# Altium schematic sheet ICY40_A02_DIPSW-DISPLAY.SchDoc
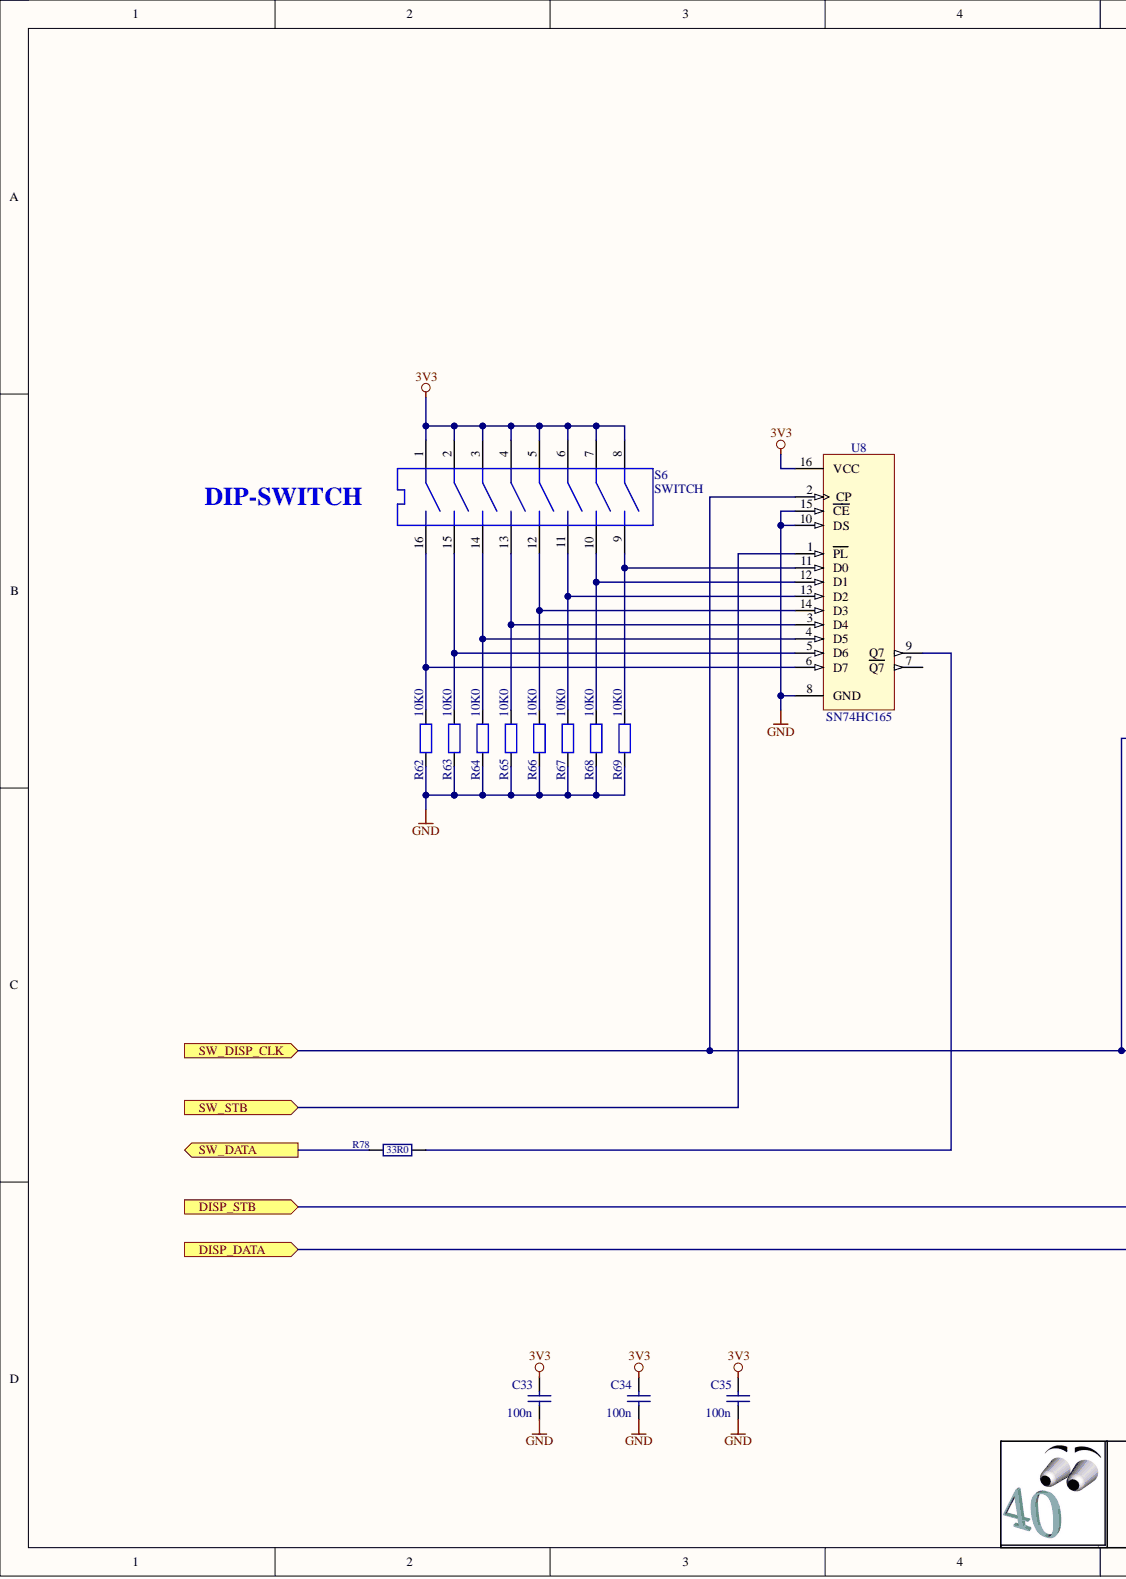
[diagram p0: ICY40_A02_DIPSW-DISPLAY.SchDoc, left]
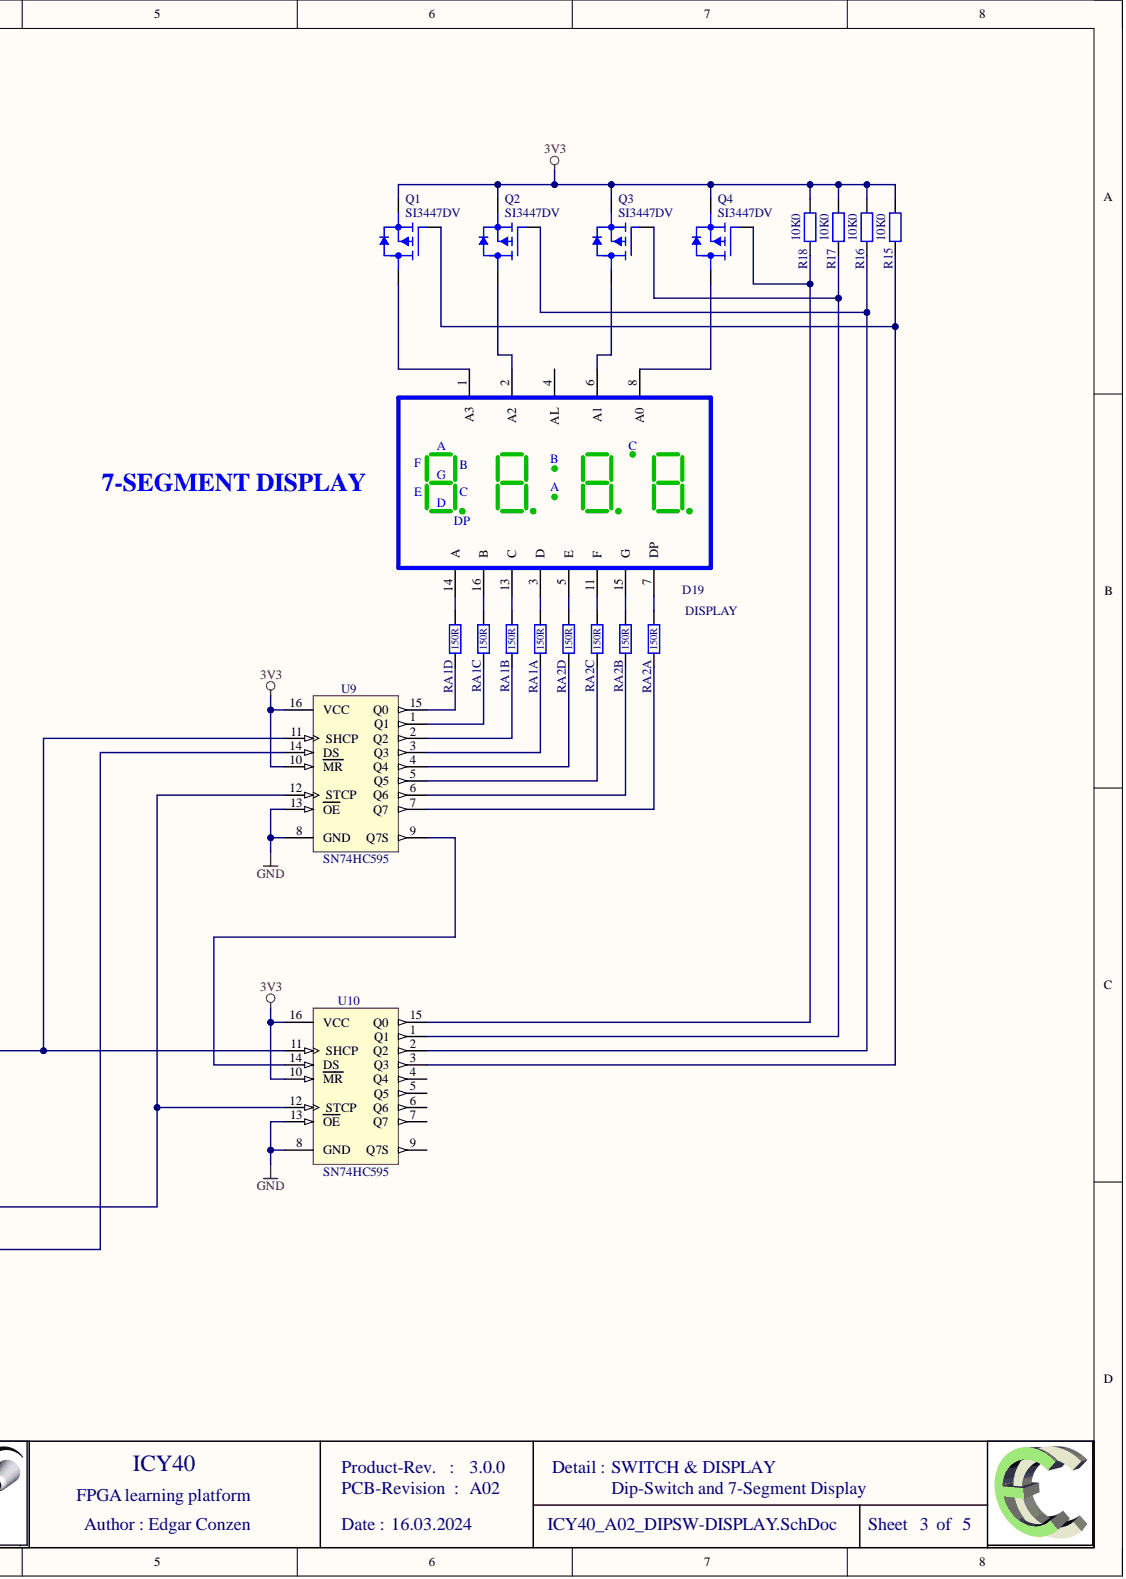
[diagram p1: ICY40_A02_DIPSW-DISPLAY.SchDoc, right]

## Parts
C33  100n  [C_SMD_0603]
C34  100n  [C_SMD_0603]
C35  100n  [C_SMD_0603]
D19  DISPLAY  [7-Segment_LITEON_LTC-4627G]
Q1  SI3447DV  [SI3447DV_SuperSOT-6]
Q2  SI3447DV  [SI3447DV_SuperSOT-6]
Q3  SI3447DV  [SI3447DV_SuperSOT-6]
Q4  SI3447DV  [SI3447DV_SuperSOT-6]
R15  10K0  [R_SMD_0603]
R16  10K0  [R_SMD_0603]
R17  10K0  [R_SMD_0603]
R18  10K0  [R_SMD_0603]
R62  10K0  [R_SMD_0603]
R63  10K0  [R_SMD_0603]
R64  10K0  [R_SMD_0603]
R65  10K0  [R_SMD_0603]
R66  10K0  [R_SMD_0603]
R67  10K0  [R_SMD_0603]
R68  10K0  [R_SMD_0603]
R69  10K0  [R_SMD_0603]
R78  33R0  [R_SMD_0603]
RA1  150R  [R_CAY16-xxxJ4]  (parts A,B,C,D)
RA2  150R  [R_CAY16-xxxJ4]  (parts A,B,C,D)
S6  DISPVAL: SWITCH  [DIP-Switch_8xON_APEM_DS08]  (hidden comment: APEM DS08)
U8  SN74HC165  [TSSOP16_4.4x5.0_0.65]
U9  SN74HC595  [TSSOP16_4.4x5.0_0.65]
U10  SN74HC595  [TSSOP16_4.4x5.0_0.65]

## Ports
DISP_DATA, DISP_STB, SW_DATA, SW_DISP_CLK, SW_STB

## Nets
3V3: C33.1 C34.1 C35.1 Q1.S Q2.S Q3.S Q4.S R15.2 R16.2 R17.2 R18.2 S6.1 S6.2 S6.3 S6.4 S6.5 S6.6 S6.7 S6.8 U8.16(VCC) U9.10(MR) U9.16(VCC) U10.10(MR) U10.16(VCC)
GND: C33.2 C34.2 C35.2 R62.1 R63.1 R64.1 R65.1 R66.1 R67.1 R68.1 R69.1 U8.8(GND) U8.10(DS) U8.15(CE) U9.8(GND) U9.13(OE) U10.8(GND) U10.13(OE)
DISP_DATA: U9.14(DS) ; port DISP_DATA
DISP_STB: U9.12(STCP) U10.12(STCP) ; port DISP_STB
SW_DATA: R78.1 ; port SW_DATA
SW_DISP_CLK: U8.2(CP) U9.11(SHCP) U10.11(SHCP) ; port SW_DISP_CLK
SW_STB: U8.1(PL) ; port SW_STB
NetD19_1: D19.1(A3) Q1.D
NetD19_2: D19.2(A2) Q2.D
NetD19_3: D19.3(D) RA1.2
NetD19_5: D19.5(E) RA2.8
NetD19_6: D19.6(A1) Q3.D
NetD19_7: D19.7(DP) RA2.2
NetD19_8: D19.8(A0) Q4.D
NetD19_11: D19.11(F) RA2.6
NetD19_13: D19.13(C) RA1.4
NetD19_14: D19.14(A) RA1.8
NetD19_15: D19.15(G) RA2.4
NetD19_16: D19.16(B) RA1.6
NetQ1_G: Q1.G R15.1 U10.3(Q3)
NetQ2_G: Q2.G R16.1 U10.2(Q2)
NetQ3_G: Q3.G R17.1 U10.1(Q1)
NetQ4_G: Q4.G R18.1 U10.15(Q0)
NetR62_2: R62.2 S6.16 U8.6(D7)
NetR63_2: R63.2 S6.15 U8.5(D6)
NetR64_2: R64.2 S6.14 U8.4(D5)
NetR65_2: R65.2 S6.13 U8.3(D4)
NetR66_2: R66.2 S6.12 U8.14(D3)
NetR67_2: R67.2 S6.11 U8.13(D2)
NetR68_2: R68.2 S6.10 U8.12(D1)
NetR69_2: R69.2 S6.9 U8.11(D0)
NetR78_2: R78.2 U8.9(Q7)
NetRA1_1: RA1.1 U9.3(Q3)
NetRA1_3: RA1.3 U9.2(Q2)
NetRA1_5: RA1.5 U9.1(Q1)
NetRA1_7: RA1.7 U9.15(Q0)
NetRA2_1: RA2.1 U9.7(Q7)
NetRA2_3: RA2.3 U9.6(Q6)
NetRA2_5: RA2.5 U9.5(Q5)
NetRA2_7: RA2.7 U9.4(Q4)
NetU9_9: U9.9(Q7S) U10.14(DS)

Not connected: D19 4(AL); U8 7(Q7); U10 4(Q4) 5(Q5) 6(Q6) 7(Q7) 9(Q7S)

## Library data of the parts
C33-C35 = C-CER_0603_100n_X7R_50V_10%: Non-Polarized Capacitor; ASSY_ALT: ---; ASSY_STEP: SMD1; ASSY_TYPE: SMD; COMPLIANCE: RoHS; Database Table Name: C-CER; ESR: n.a.; LIB_STATE: Preliminary; MAT: X7R; MFG_NAME: various…; NB: B; TCC: 15%; TEMP_STORE: -55°C to +85°C; TOL: 10%; TYPE: C; VALUE: 0,1; VOLTAGE: 50V; ASSY_NOTE: ---; CASE: 0603; Component Kind: Standard; ComponentLink1Description: Datasheet; ComponentLink3Description: Manufacturer Link; D-ID: C-CER_0603_100n_X7R_50V_10%; DISPVAL: 100n; Library Name: C.DbLib; MFG_PARTNR: various…; MSL: 1; NOTES: ---; TEMP_USE: -55°C to +85°C; USAGE: ---
D19 = 7-Segment_LITEON_LTC-4627G: LED-DISPLAY 7 Segments, 4 Digits, CA, muxed, green; Manufacturer: Liteon; I-nom: 0.064; Component Kind: Standard; D-ID: 7-Segment_LITEON_LTC-4627G; DISPVAL: DISPLAY; Library Name: Icy40.SchLib
Q1-Q4 = SI3447DV: P-Channel 1.8V MOSFET SuperSOT-6; Manufacturer: Fairchild; Component Kind: Standard; D-ID: SI3447DV; DISPVAL: SI3447DV; Library Name: Icy40.SchLib
R15-R18 R62-R69 = R_0603_10K0_1%_TCR100_0.1W: Resistor; IM_PARTNR: 00001313; ASSY_ALT: ---; ASSY_STEP: SMD1; ASSY_TYPE: SMD; COMPLIANCE: ROHS; Database Table Name: R; MFG_NAME: various…; NB: B; POWER: 0.1W; TCR: 100; TEMP_STORE: n/A; TEMP_USE: -55° .. +155°C; TOL: 1%; VALUE: 10000; VOLTAGE: 75V; ASSY_NOTE: ---; CASE: 0603; Component Kind: Standard; ComponentLink1Description: Datasheet; ComponentLink2Description: Solder Profile; ComponentLink3Description: Manufacturer Link; D-ID: R_0603_10K0_1%_TCR100_0.1W; DISPVAL: 10K0; Library Name: R.DbLib; LIFECYCLE: Active; MFG_PARTNR: various…; MSL: 1; TYPE: R
R78 = R_0603_33R0_1%_TCR100_0.1W: Resistor; IM_PARTNR: TBD; ASSY_ALT: ---; ASSY_STEP: SMD1; ASSY_TYPE: SMD; COMPLIANCE: ROHS; Database Table Name: R; MFG_NAME: various…; NB: B; POWER: 0.1W; TCR: 100; TEMP_STORE: n/A; TEMP_USE: -55° .. +155°C; TOL: 1%; VALUE: 33; VOLTAGE: 75V; ASSY_NOTE: ---; CASE: 0603; Component Kind: Standard; ComponentLink1Description: Datasheet; ComponentLink2Description: Solder Profile; ComponentLink3Description: Manufacturer Link; D-ID: R_0603_33R0_1%_TCR100_0.1W; DISPVAL: 33R0; Library Name: R.DbLib; LIFECYCLE: Active; MFG_PARTNR: various…; MSL: 1; TYPE: R
RA1 RA2 = R_4x_0603_150R_1%_TCR200_0.0625W_CONVEX: 4x 0603 150R 1% TCR200 4x 0.0625W Convex; Component Kind: Standard; D-ID: R_4x_0603_150R_1%_TCR200_0.0625W_CONVEX; DISPVAL: 150R; Library Name: Icy40.SchLib
S6 = DIP-Switch_8xON_APEM_DS08: Component Kind: Standard; D-ID: DIP-Switch_8xON_APEM_DS08; Description: DIP-Switch_8xON_APEM_DS08; Manufacturer: APEM; Library Name: Icy40.SchLib
U8 = SN74HC165PW: ShiftReg 8-bit PI/SI/SO, 2.0-6.0V, TSSOP16; Manufacturer: Texas Instruments; Component Kind: Standard; D-ID: SN74HC165PW; DISPVAL: SN74HC165; Library Name: Icy40.SchLib
U9 U10 = SN74HC595PW: ShiftReg 8-bit SI/PO/SO, 2.0-6.0V, TSSOP16; Manufacturer: Texas Instruments; Component Kind: Standard; D-ID: SN74HC595PW; DISPVAL: SN74HC595; Library Name: Icy40.SchLib
